# Altium schematic sheet Bluetooth.SchDoc
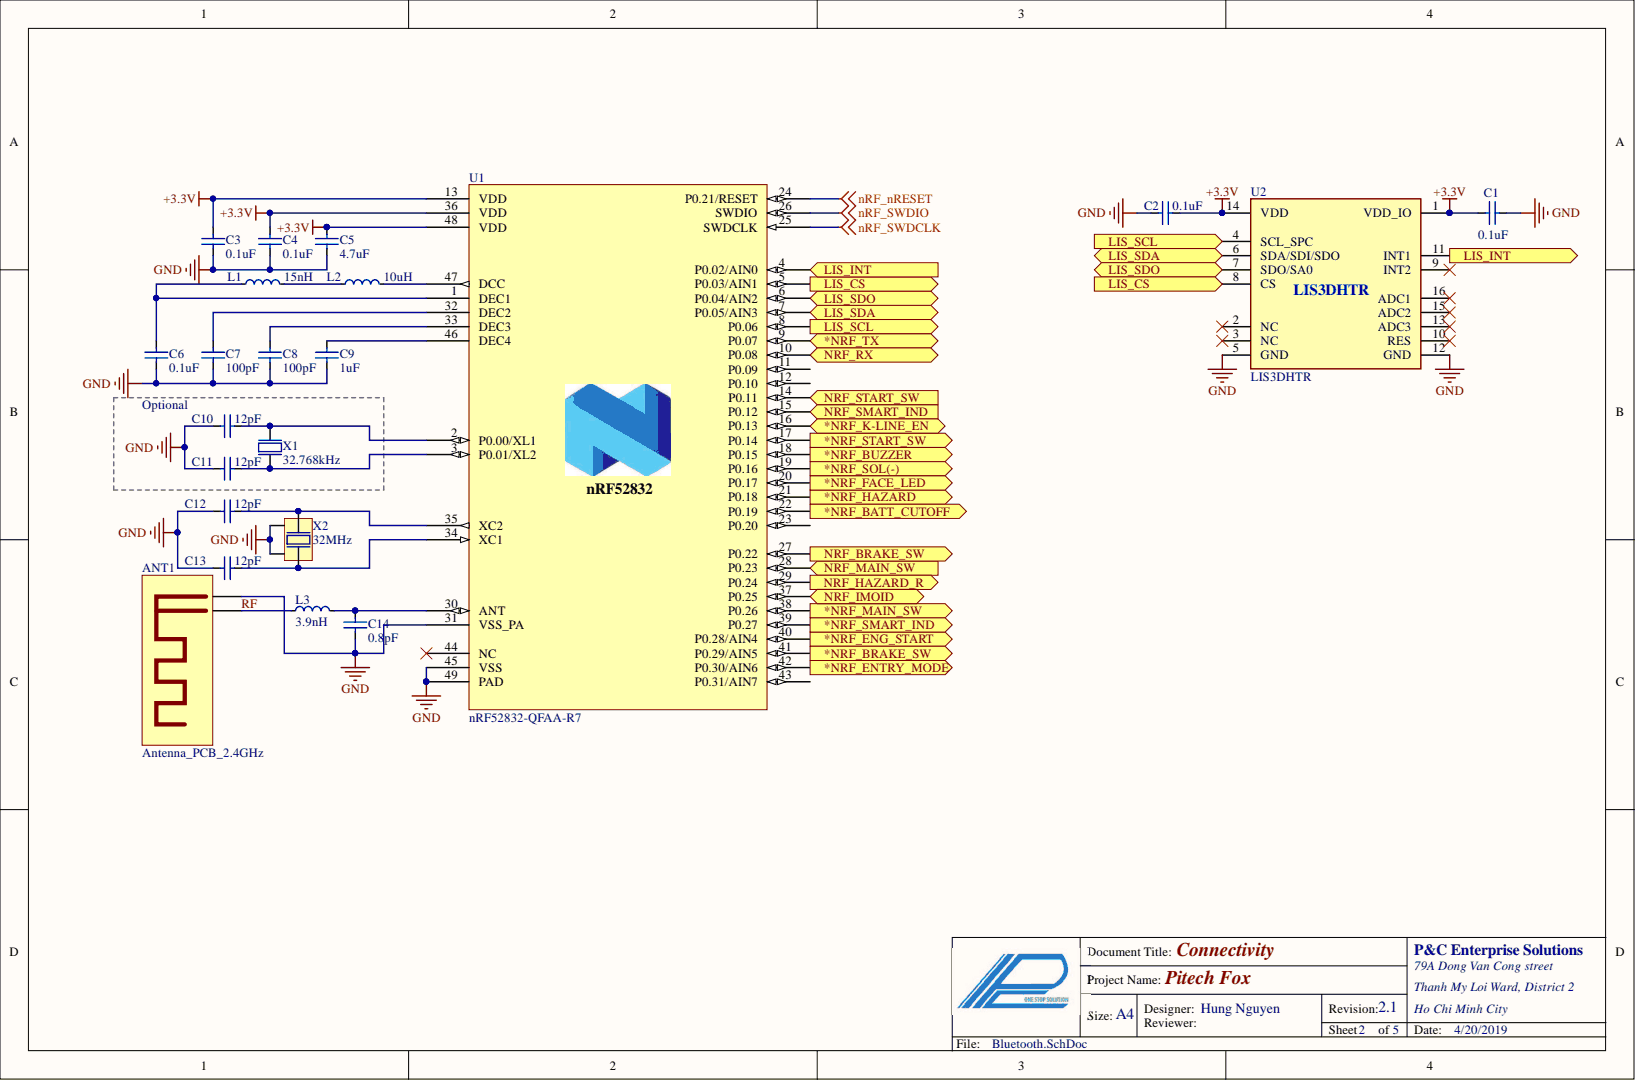
[diagram p0: Bluetooth.SchDoc]

## Parts
ANT1  Antenna_PCB_2.4GHz  [SMALL_PCBANT_2.4GHz_15x5mm]  (no BOM)
C1  0.1uF  [C0603 (1608 metric)]
C2  0.1uF  [C0603 (1608 metric)]
C3  0.1uF  [C0603 (1608 metric)]
C4  0.1uF  [C0603 (1608 metric)]
C5  4.7uF  [C0603 (1608 metric)]
C6  0.1uF  [C0603 (1608 metric)]
C7  100pF  [C0402 (1005 Metric)]
C8  100pF  [C0402 (1005 Metric)]
C9  1uF  [C0603 (1608 metric)]
C10  12pF  [C0402 (1005 Metric)]  (no BOM)
C11  12pF  [C0402 (1005 Metric)]  (no BOM)
C12  12pF  [C0402 (1005 Metric)]
C13  12pF  [C0402 (1005 Metric)]
C14  0.8pF  [C0402 (1005 Metric)]
L1  15nH  [L0402 (1005 Metric)]
L2  10uH  [L0603 (1608 Metric)]
L3  3.9nH  [L0402 (1005 Metric)]
U1  nRF52832-QFAA-R7  [QFN-48 6x6mm]
U2  LIS3DHTR  [LIS3DH_LGA16]
X1  32.768kHz  [XTAL_2012]  (no BOM)
X2  32MHz  [BT-XTAL_2016]

## Ports
*NRF_BATT_CUTOFF, *NRF_BRAKE_SW, *NRF_BUZZER, *NRF_ENG_START, *NRF_ENTRY_MODE, *NRF_FACE_LED, *NRF_HAZARD, *NRF_K-LINE_EN, *NRF_MAIN_SW, *NRF_SMART_IND, *NRF_SOL(-), *NRF_START_SW, *NRF_TX, LIS_CS, LIS_INT, LIS_SCL, LIS_SDA, LIS_SDO, NRF_BRAKE_SW, NRF_HAZARD_R, NRF_IMOID, NRF_MAIN_SW, NRF_RX, NRF_SMART_IND, NRF_START_SW

## Nets
+3.3V: C1.1 C2.2 C3.2 C4.2 C5.2 U1.13(VDD) U1.36(VDD) U1.48(VDD) U2.1(VDD_IO) U2.14(VDD)
GND: ANT1.1 C1.2 C2.1 C3.1 C4.1 C5.1 C6.1 C7.1 C8.1 C9.1 C10.1 C11.1 C12.1 C13.1 C14.1 U1.31(VSS_PA) U1.45(VSS) U1.49(PAD) U2.5(GND) U2.12(GND) X2.2 X2.4
nRF_nRESET: U1.24(P0.21/RESET)
nRF_SWDCLK: U1.25(SWDCLK)
nRF_SWDIO: U1.26(SWDIO)
*NRF_BATT_CUTOFF: U1.22(P0.19) ; port *NRF_BATT_CUTOFF
*NRF_BRAKE_SW: U1.41(P0.29/AIN5) ; port *NRF_BRAKE_SW
*NRF_BUZZER: U1.18(P0.15) ; port *NRF_BUZZER
*NRF_ENG_START: U1.40(P0.28/AIN4) ; port *NRF_ENG_START
*NRF_ENTRY_MODE: U1.42(P0.30/AIN6) ; port *NRF_ENTRY_MODE
*NRF_FACE_LED: U1.20(P0.17) ; port *NRF_FACE_LED
*NRF_HAZARD: U1.21(P0.18) ; port *NRF_HAZARD
*NRF_K-LINE_EN: U1.16(P0.13) ; port *NRF_K-LINE_EN
*NRF_MAIN_SW: U1.38(P0.26) ; port *NRF_MAIN_SW
*NRF_SMART_IND: U1.39(P0.27) ; port *NRF_SMART_IND
*NRF_SOL(-): U1.19(P0.16) ; port *NRF_SOL(-)
*NRF_START_SW: U1.17(P0.14) ; port *NRF_START_SW
*NRF_TX: U1.9(P0.07) ; port *NRF_TX
LIS_CS: U1.5(P0.03/AIN1) U2.8(CS) ; port LIS_CS
LIS_INT: U1.4(P0.02/AIN0) U2.11(INT1) ; port LIS_INT
LIS_SCL: U1.8(P0.06) U2.4(SCL_SPC) ; port LIS_SCL
LIS_SDA: U1.7(P0.05/AIN3) U2.6(SDA/SDI/SDO) ; port LIS_SDA
LIS_SDO: U1.6(P0.04/AIN2) U2.7(SDO/SA0) ; port LIS_SDO
NRF_BRAKE_SW: U1.27(P0.22) ; port NRF_BRAKE_SW
NRF_HAZARD_R: U1.29(P0.24) ; port NRF_HAZARD_R
NRF_IMOID: U1.37(P0.25) ; port NRF_IMOID
NRF_MAIN_SW: U1.28(P0.23) ; port NRF_MAIN_SW
NRF_RX: U1.10(P0.08) ; port NRF_RX
NRF_SMART_IND: U1.15(P0.12) ; port NRF_SMART_IND
NRF_START_SW: U1.14(P0.11) ; port NRF_START_SW
RF: ANT1.2 L3.1
NetC6_2: C6.2 L1.1 U1.1(DEC1)
NetC7_2: C7.2 U1.32(DEC2)
NetC8_2: C8.2 U1.33(DEC3)
NetC9_2: C9.2 U1.46(DEC4)
NetC10_2: C10.2 U1.2(P0.00/XL1) X1.1
NetC11_2: C11.2 U1.3(P0.01/XL2) X1.2
NetC12_2: C12.2 U1.35(XC2) X2.1
NetC13_2: C13.2 U1.34(XC1) X2.3
NetC14_2: C14.2 L3.2 U1.30(ANT)
NetL1_2: L1.2 L2.1
NetL2_2: L2.2 U1.47(DCC)

Not connected: U1 11(P0.09) 12(P0.10) 23(P0.20) 43(P0.31/AIN7) 44(NC); U2 2(NC) 3(NC) 9(INT2) 10(RES) 13(ADC3) 15(ADC2) 16(ADC1)

## Library data of the parts
C1-C4 C6 = TMK107BJ104KA-T: Multilayer Ceramic Capacitors MLCC - SMD/SMT 0.1uF 25V X7R +/-10% 0603 Gen Purp; Mfr: Taiyo Yuden; Tolerance: 10%; Case: 0603 (1608 metric); Component Kind: Standard; Dielectric: X7R; Library Name: CeramicCapacitor.SchLib; Mfr Part Number: TMK107BJ104KA-T; Supplier 1: Mouser; Supplier Part Number 1: 963-TMK107BJ104KA-T; Value: 0.1uF; Voltage: 25V
C5 = JMK107BJ475KA-T: Multilayer Ceramic Capacitors MLCC - SMD/SMT 4.7uF 6.3V X5R +/-10% 0603 Gen Purp; Mfr: Taiyo Yuden; Tolerance: 10%; Case: 0603 (1608 metric); Component Kind: Standard; Dielectric: X5R; Library Name: CeramicCapacitor.SchLib; Mfr Part Number: JMK107BJ475KA-T; Supplier 1: Mouser; Supplier Part Number 1: 963-JMK107BJ475KA-T; Value: 4.7uF; Voltage: 6.3V
C7 C8 = UMK105CH101JVHF: Multilayer Ceramic Capacitors MLCC - SMD/SMT 100pF 50V C0H +/-5% 0402 AEC-Q200; Mfr: Taiyo Yuden; Tolerance: 5%; Voltage: 50VDC; Case: 0402 (1005 metric); Component Kind: Standard; Dielectric: C0H; Library Name: CeramicCapacitor.SchLib; Mfr Part Number: UMK105CH101JVHF; Supplier 1: Mouser; Supplier Part Number 1: 963-UMK105CH101JVHF; Value: 100pF
C9 = LMK107B7105KA-T: Multilayer Ceramic Capacitors MLCC - SMD/SMT 1uF 10V X7R +/-10% 0603; Mfr: Taiyo Yuden; Tolerance: 10%; Case: 0603 (1608 metric); Component Kind: Standard; Dielectric: X7R; Library Name: CeramicCapacitor.SchLib; Mfr Part Number: LMK107B7105KA-T; Supplier 1: Mouser; Supplier Part Number 1: 963-LMK107B7105KA-T; Value: 1uF; Voltage: 10V
C10-C13 = UMK105CG120JV-F: Multilayer Ceramic Capacitors MLCC - SMD/SMT 12pF 50V C0G +/-5% 0402; Mfr: Taiyo Yuden; Dielectric: C0G (NP0); Tolerance: 5%; Voltage: 50VDC; Case: 0402 (1005 metric); Library Name: CeramicCapacitor.SchLib; Mfr Part Number: UMK105CG120JV-F; Supplier 1: Mouser; Supplier Part Number 1: 963-UMK105CG120JV-F; Value: 12pF
  C12 C13: Component Kind: Standard
C14 = GCM1555C1HR80WA16D: Multilayer Ceramic Capacitors MLCC - SMD/SMT 0.8pF 50V C0G +/-0.05pF 0402; Mfr: Murata Electronics; Dielectric: C0G (NP0); Tolerance: 0.05pF; Case: 0402 (1005 metric); Component Kind: Standard; Library Name: CeramicCapacitor.SchLib; Mfr Part Number: GCM1555C1HR80WA16D; Supplier 1: Mouser; Supplier Part Number 1: 81-GCM1555C1HR80WA6D; Value: 0.8pF; Voltage: 50V
L1 = HK100515NK-T: Fixed Inductors 0402 15nH 460mOhms +/-10%Tol 320mA HiQ; Mfr: Taiyo Yuden; Tolerance: 10%; Component Kind: Standard; Library Name: Inductor.SchLib; Maximum DC Current: 320mA; Maximum DC Resistance: 460mOhm; Mfr Part Number: HK100515NK-T; Package: 0402 (1005 metric); Supplier 1: Mouser; Supplier Part Number 1: 963-HK100515NK-T; Value: 15nH
L2 = LBMF1608T100K: Fixed Inductors 0603 10uH 468mOhms +/-10%Tol 80mA; Mfr: Taiyo Yuden; Tolerance: 10%; Component Kind: Standard; Library Name: Inductor.SchLib; Maximum DC Current: 80mA; Maximum DC Resistance: 468mOhm; Mfr Part Number: LBMF1608T100K; Package: 0603 (1608 metric); Supplier 1: Mouser; Supplier Part Number 1: 963-LBMF1608T100K; Value: 10uH
L3 = HK10053N9J-T: Fixed Inductors 0402 3.9nH 210mOhms +/-5%Tol 500mA HiQ; Mfr: Taiyo Yuden; Tolerance: 5%; Component Kind: Standard; Library Name: Inductor.SchLib; Maximum DC Current: 500mA; Maximum DC Resistance: 210mOhm; Mfr Part Number: HK10053N9J-T; Package: 0402 (1005 metric); Supplier 1: Mouser; Supplier Part Number 1: 963-HK10053N9J-T; Value: 3.9nH
U1 = (no symbol name): Multi-protocol Bluetooth Low Energy, ANT and 2.4GHz proprietary system-on-chip; Mfr: Nordic Semiconductor; Artikkelnr Noca: N.A.; Value: nRF52832-FQN; Artikkelnr Simpro: N.A.; Component Kind: Standard; Footprint Doc: WLCSP_C50; LatestRevisionDate: 2016-03-11; Library Name: RF.SchLib; Mfr Part Number: nRF52832-QFAA-R7; Part number IPS: N.A.; Pin Count: 54; Published: 2016-03-11; Supplier 1: Mouser; Supplier Part Number 1: 949-NRF52832-QFAA-R7; Publisher: Nordic Semiconductor
U2 = LIS3DHTR: STMicroelectronics Accelerometers MEMS Ultra Low-Power 3-Axes "Nano"; Interface Type: I2C, SPI; Output Type: Digital SPI; Component Kind: Standard; Library Name: Sensor.SchLib; Mfr: STMicroelectronics; Mfr Part Number: LIS3DHTR; Package: LGA-16; Supplier 1: Mouser; Supplier Part Number 1: 511-LIS3DHTR
X1 = XTAL_SMD2012_32.768kHz_9pF_Seiko: XTAL SMD 2012, 32.768kHz, Cl=9pF, Total Tol: ±50ppm; Manufacturer: Seiko; Manufacturer Part Number: SC20S-9.0PF20PPM; Artikkelnr Noca: N.A.; Database Table Name: Crystal_Osc$; Note: XTAL SMD 32.768kHz, 2012; Artikkelnr Simpro: N.A.; Datasheet: http://www.sii.co.jp/en/quartz/files/2013/03/file_PRODUCT_MASTER_50811_GRAPHIC03.pdf; Footprint Doc: XTAL_2012; LatestRevisionDate: 2015-10-15; Library Name: Nordic Discrete DB.DbLib; Part Number: XTAL_SMD2012_32.768kHz_9pF_Seiko; Pin Count: 2; Published: 2015-10-15; Value: 32.768kHz; Publisher: Nordic Semiconductor
X2 = (no symbol name): Crystals 32MHz 10ppm 8pF -20C +75C; Mfr: Epson; Mfr Part Number: FA-128 32.0000MF10Z-AJ3; Tolerance: 30ppm; Component Kind: Standard; Frequency Stability: 10ppm; Library Name: Crystal.SchLib; Load Capacitance: 8 pF; Package: 2 mm x 1.6 mm; Supplier 1: Mouser; Supplier Part Number 1: 732-FA12832.F10Z-AJ3; Value: 32MHz
ANT1 = Antenna_PCB_2.4GHz: Library Name: CTCNGH_Lib.SchLib
